# Altium schematic sheet Amplifier.SchDoc
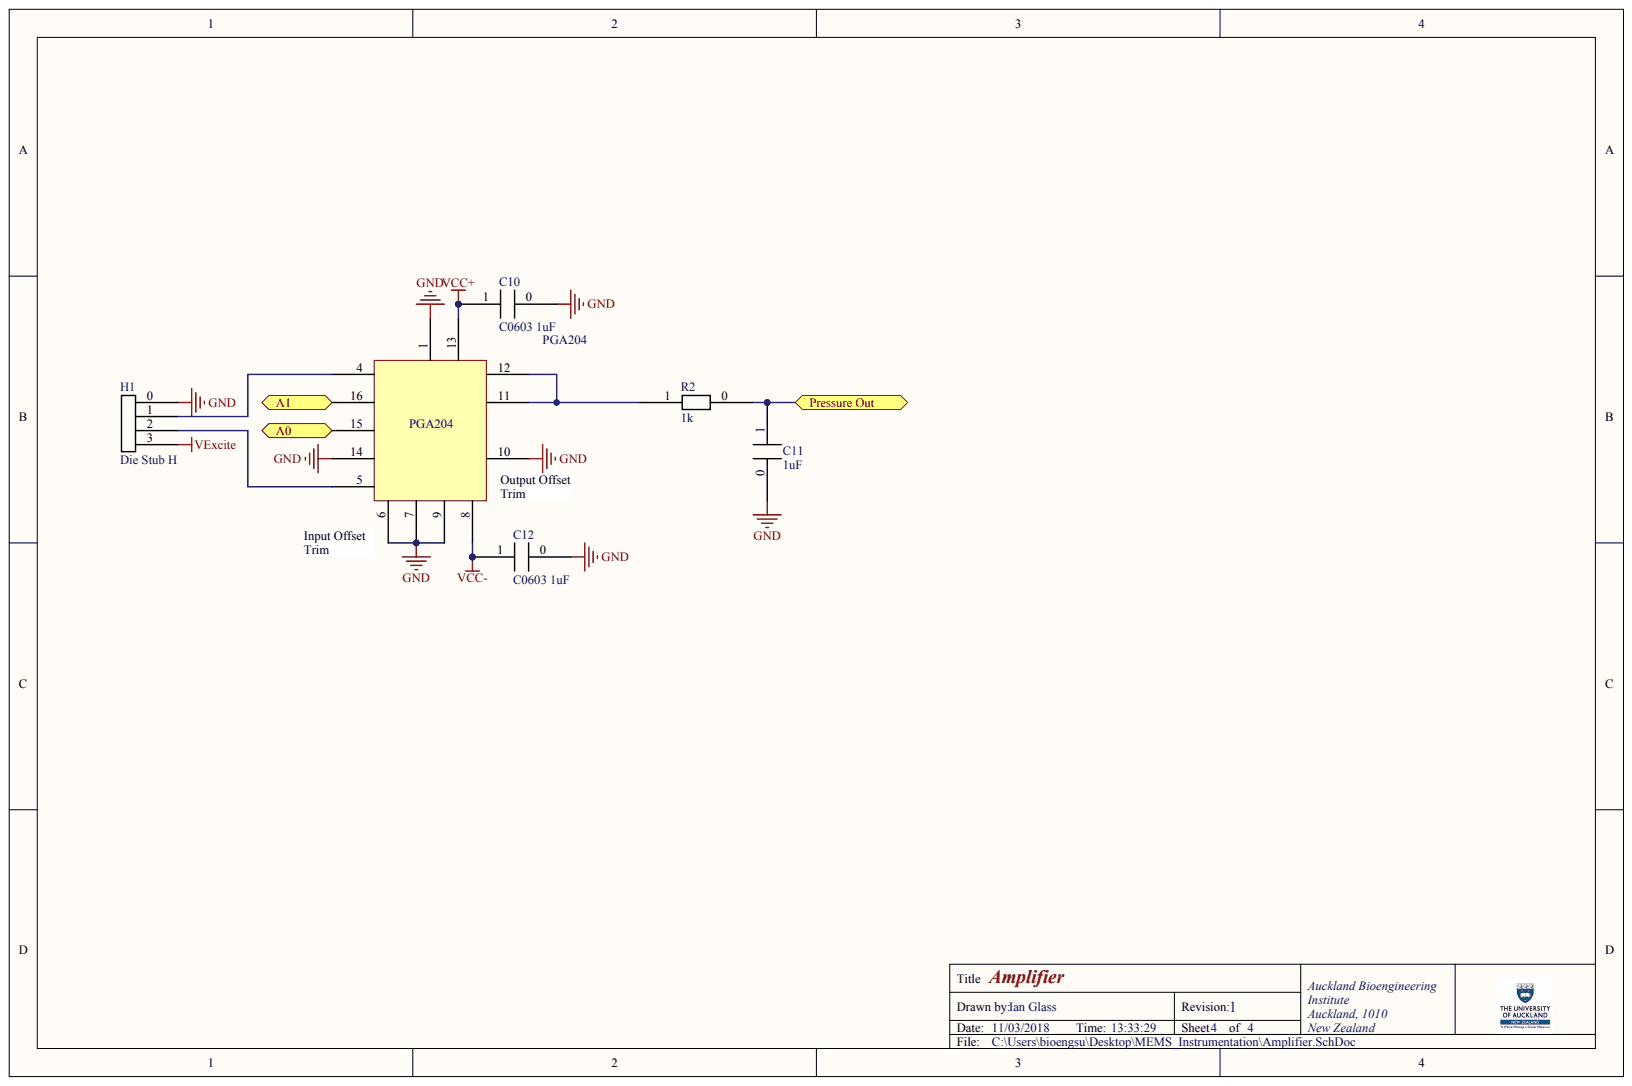
[diagram p0: Amplifier.SchDoc]

## Parts
C10  C0603 1uF
C11  1uF
C12  C0603 1uF
H1  Die Stub H
PGA204  PGA204
R2  1k

## Ports
A0, A1, PRESSURE OUT

## Nets
GND: C10.0 C11.0 C12.0 H1.0 PGA204.1 PGA204.6 PGA204.7 PGA204.9 PGA204.10 PGA204.14
VCC+: C10.1 PGA204.13
VCC-: C12.1 PGA204.8
VEXCITE: H1.3
A0: PGA204.15 ; port A0
A1: PGA204.16 ; port A1
NetC11_1: C11.1 R2.0 ; port PRESSURE OUT
NetH1_1: H1.1 PGA204.4
NetH1_2: H1.2 PGA204.5
NetPGA204_11: PGA204.11 PGA204.12 R2.1
